# Altium schematic sheet Driver.SchDoc
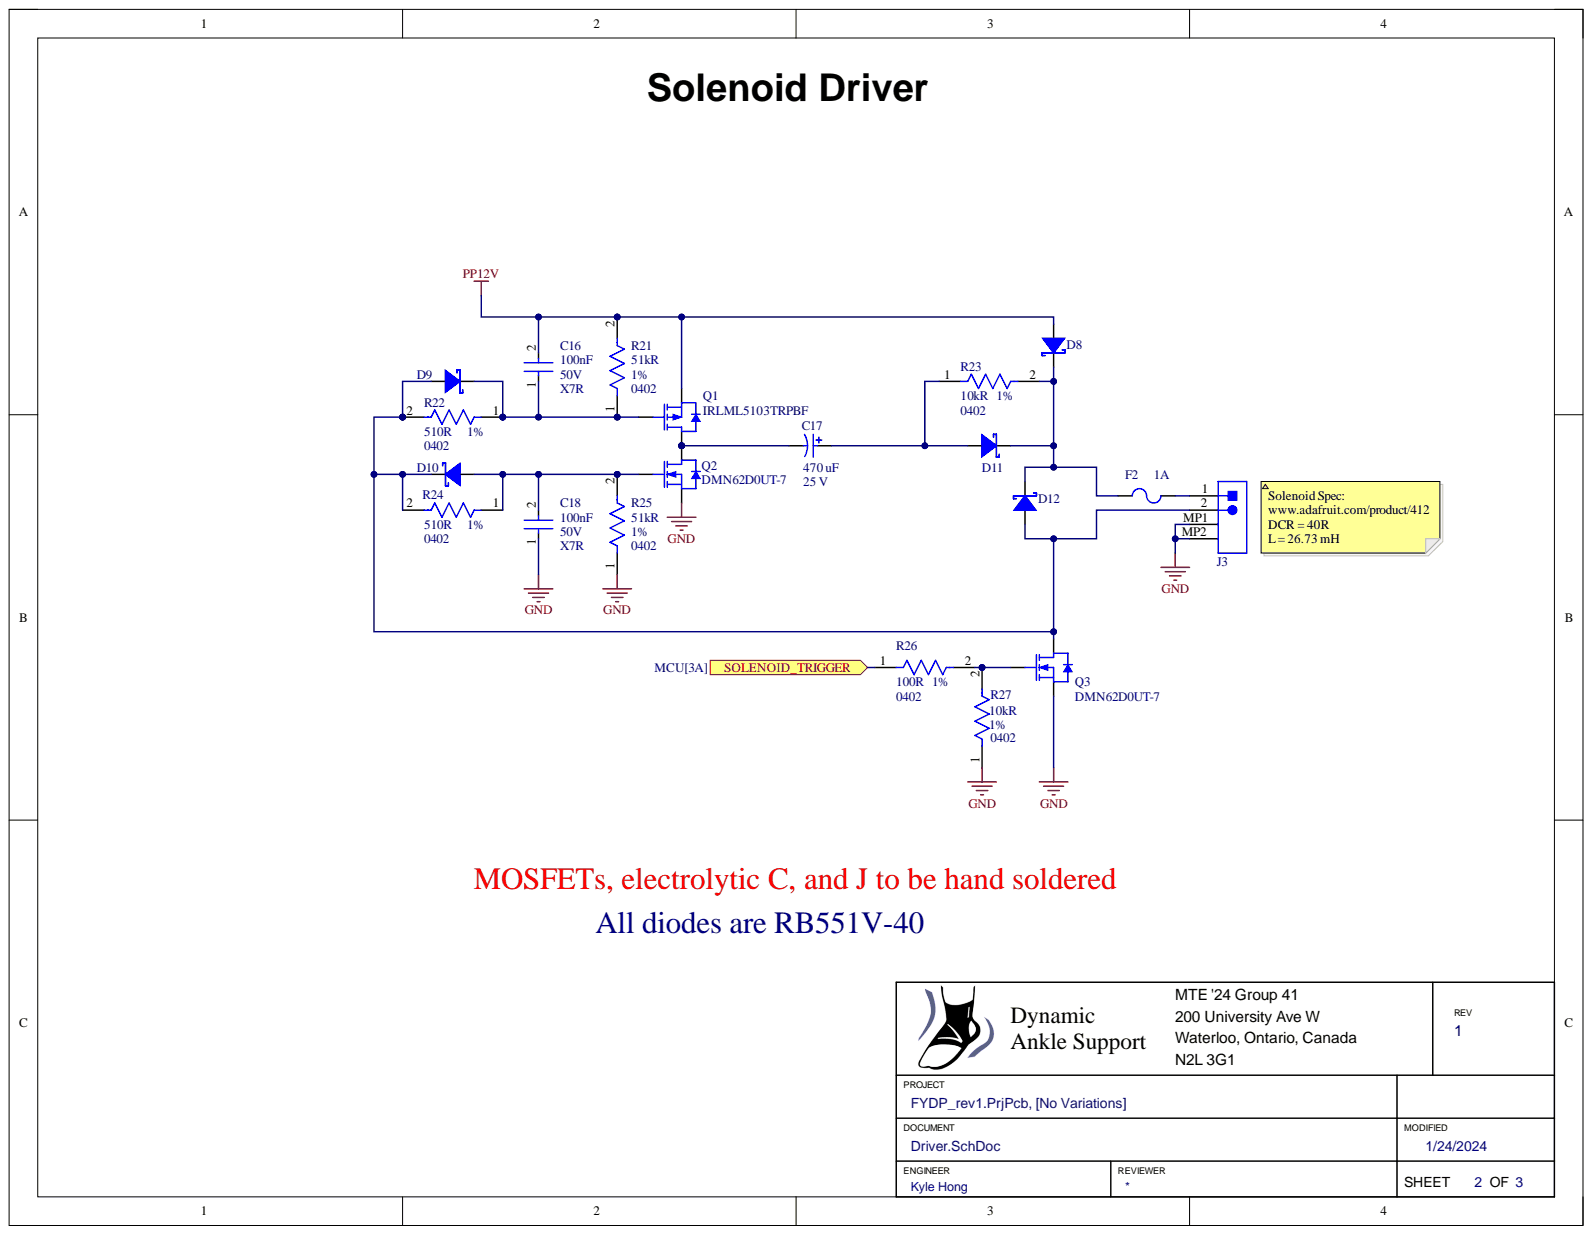
[diagram p0: Driver.SchDoc]

## Parts
C16  Capacitance: 100nF  Dielectric: X7R  Voltage Rating: 50V  [CAP0402]  (hidden comment: CL05B104KB54PNC)
C17  Rated Capacitance: 470 uF  Rated Voltage: 25 V  [NIC-CAPA2-10X10_V]  (hidden comment: UUD1E471MNL1GS)
C18  Capacitance: 100nF  Dielectric: X7R  Voltage Rating: 50V  [CAP0402]  (hidden comment: CL05B104KB54PNC)
D8  RB551V-40  [SOD-323]
D9  RB551V-40  [SOD-323]
D10  RB551V-40  [SOD-323]
D11  RB551V-40  [SOD-323]
D12  RB551V-40  [SOD-323]
F2  Current Rating: 1A  [FUSE_0603]  (hidden comment: 0467001.NRHF)
J3  S2B-PH-SM4-TB(LF)(SN)  [JST- S2B-PH-SM4-TB(LF)(SN)-2_V]  (value not drawn)
Q1  IRLML5103TRPBF  [SOT23-3]
Q2  DMN62D0UT-7  [SOT-523]
Q3  DMN62D0UT-7  [SOT-523]
R21  Resistance: 51kR  Tolerance: 1%  [RES0402]  (hidden comment: 0402WGF5102TCE)
R22  Resistance: 510R  Tolerance: 1%  [RES0402]  (hidden comment: 0402WGF5100TCE)
R23  Package: 0402  Resistance: 10kR  Tolerance: 1%  [RES0402]  (hidden comment: 0402WGF1002TCE)
R24  Package: 0402  Resistance: 510R  Tolerance: 1%  [RES0402]  (hidden comment: 0402WGF5100TCE)
R25  Package: 0402  Resistance: 51kR  Tolerance: 1%  [RES0402]  (hidden comment: 0402WGF5102TCE)
R26  Package: 0402  Resistance: 100R  Tolerance: 1%  [RES0402]  (hidden comment: 0402WGF1000TCE)
R27  Package: 0402  Resistance: 10kR  Tolerance: 1%  [RES0402]  (hidden comment: 0402WGF1002TCE)

## Ports
SOLENOID_TRIGGER

## Nets
GND: C18.1 J3.MP1 J3.MP2 Q2.2 Q3.2 R25.1 R27.1
PP12V: C16.2 D8.2 Q1.2 R21.2
SOLENOID_TRIGGER: R26.1 ; port SOLENOID_TRIGGER
NetC16_1: C16.1 D9.1 Q1.1 R21.1 R22.1
NetC17_1: C17.1 D11.2 R23.1
NetC17_2: C17.2 Q1.3 Q2.3
NetC18_2: C18.2 D10.2 Q2.1 R24.1 R25.2
NetD8_1: D8.1 D11.1 D12.1 F2.1 R23.2
NetD9_2: D9.2 D10.1 D12.2 J3.2 Q3.3 R22.2 R24.2
NetF2_2: F2.2 J3.1
NetQ3_1: Q3.1 R26.2 R27.2

## Library data of the parts
C16 C18 = CAP0402: 50V 100nF X7R ±10% 0402  Multilayer Ceramic Capacitors MLCC - SMD/SMT ROHS; Manufacturer: Samsung Electro-Mechanics; Tolerance: ±20%; Component Kind: Standard; Manufacturer Part Number: CL05B104KB54PNC; Package: 0402; Library Name: FYDP_library_sch.SchLib
C17 = CMP-0687-00002-1: Aluminum Electrolytic Capacitor, UD Series, Low Impedance, 470 uF, 25 V, + / - 20%, -55 to 105 degC, Chip Type, 10 x 10 mm D x L, Pb-Free, Reel; Manufacturer: Nichicon; Endurance Time: 5000 h; Impedance: 0.09 Ohm; Packing: Dia382mm Reel; Rated Ripple Current (at 100kHz): 670 mA; Tolerance Rated Capacitance: -20 to 20%; Component Kind: Standard; ComponentLink1Description: Manufacturer URL; ComponentLink1URL: http://www.nichicon.co.jp/; ComponentLink2Description: Datasheet; ComponentLink2URL: http://products.nichicon.co.jp/en/pdf/XJA043/e-ud.pdf; ComponentLink3Description: Package Specification; ComponentLink3URL: http://products.nichicon.co.jp/en/pdf/XJA043/e-ud.pdf; DatasheetVersion: 08/2012; Diameter: 10 mm; Length: 10 mm; Library Name: Altium Content Vault; Mounting Technology: Surface Mount; PackageDescription: 2-Pin Surface Mount Device, Diameter 10 mm, Height 10 mm, Pitch 8 mm; PackageReference: CAPAE-D10H10; PartNumber: UUD1E471MNL1GS; RoHS: TRUE
D8-D12 = RB551V-40: 40V 470mV@500mA 0.5A SOD-323  Schottky Barrier Diodes (SBD) ROHS; Manufacturer: Taiwan Semiconductor; Vf (Max): 470mV @ 0.5A; Component Kind: Standard; Io: 0.5A; Manufacturer Part Number: RB551V-40; Mounting Type: SMD; Package/Footprint: SOD-323; Vr (Max): 40V; Library Name: FYDP_library_sch.SchLib
F2 = FUSE0603: 50A SMD Fuse 1A 32V 32V 0603  Fuses ROHS; Manufacturer: Littelfuse; Component Kind: Standard; Manufacturer Part Number: 0467001.NRHF; Package: 0603; Supplier 1: Future Electronics; Supplier Part Number 1: 6130137; Voltage Rating: 32V; Library Name: FYDP_library_sch.SchLib
J3 = CMP-1755-00001-1: Male Header, Pitch 2 mm, 1 x 2 Position, Height 5.5 mm, -25 to 85 degC, RoHS, Tape and Reel; Current Rating: 3 A; Colour: Black; Component Kind: Standard; ComponentLink1Description: Manufacturer URL; ComponentLink1URL: http://www.jst.com/home2.html; ComponentLink2Description: Datasheet; ComponentLink2URL: http://www.jst-mfg.com/product/pdf/eng/ePH.pdf; Contact Finish: Lead; Contact Material: Bronze; Contact Plating: Tin; DatasheetVersion: Dec-12; Height: 8.13 mm; Lead Pitch: 0.1 inch; Length: 101.19 mm; Library Name: Altium Content Vault; Max Operating Temperature: 105degC; Min Operating Temperature: -65degC; Mount: Through Hole; Package Quantity: 100; PackageDescription: Male Header, Pitch 2 mm, 1 x 2 Position, Height 5.5 mm; PackageReference: S2B-PH-SM4-TB(LF)(SN)-2; Packaging: Bulk; Pins: 40; Radiation Hardening: No; RoHSCompliant: True; Width: 2.34 mm
Q1 = IRLML5103TRPBF: P-Channel 30 V 760mA (Ta) 540mW (Ta) Surface Mount Micro3™/SOT-23; Manufacturer 1: Infineon Technologies; Vdss: 30V; Vgs (Max): ±20V; Component Kind: Standard; Id: 760mA; Manufacturer Part Number 1: IRLML5103TRPBF; Mounting Type: SMD; Power Dissipation (Max): 540mW (Ta); Supplier 1: Mouser; Supplier Part Number 1: 942-IRLML5103TRPBF; Library Name: FYDP_library_sch.SchLib
Q2 Q3 = DMN62D0UT-7: NFET 60 V 320mA (Ta) 230mW (Ta) Surface Mount SOT-523; Vdss: 60V; Vgs (Max): ±20V; Vgs(th) (Max) @ Id: 1V @ 250uA; Component Kind: Standard; Id @ 25°C: 320mA; Package: SOT-523; Supplier 1: Digikey; Supplier Part Number 1: DMN62D0UT-7DICT-ND; Library Name: FYDP_library_sch.SchLib
R21 R25 = RES0402: 62.5mW Thick Film Resistors ±100ppm/℃ ±1% 51kΩ 0402  Chip Resistor - Surface Mount ROHS; Manufacturer: UNI-ROYAL(Uniroyal Elec); Component Kind: Standard; Manufacturer Part Number: 0402WGF5102TCE; Power Rating: 62.5mW; Library Name: FYDP_library_sch.SchLib
  R21: Package: 0402
R22 R24 = RES0402: 62.5mW Thick Film Resistors ±100ppm/℃ ±1% 510Ω 0402  Chip Resistor - Surface Mount ROHS; Manufacturer: UNI-ROYAL(Uniroyal Elec); Component Kind: Standard; Manufacturer Part Number: 0402WGF5100TCE; Power Rating: 62.5mW; Library Name: FYDP_library_sch.SchLib
  R22: Package: 0402
R23 R27 = RES0402: 62.5mW Thick Film Resistors ±100ppm/℃ ±1% 10kΩ 0402  Chip Resistor - Surface Mount ROHS; Manufacturer: UNI-ROYAL(Uniroyal Elec); Component Kind: Standard; Manufacturer Part Number: 0402WGF1002TCE; Power Rating: 62.5mW; Library Name: FYDP_library_sch.SchLib
R26 = RES0402: 62.5mW Thick Film Resistors ±1% ±200ppm/℃ 100Ω 0402  Chip Resistor - Surface Mount ROHS; Manufacturer: UNI-ROYAL(Uniroyal Elec); Component Kind: Standard; Manufacturer Part Number: 0402WGF1000TCE; Power Rating: 62.5mW; Library Name: FYDP_library_sch.SchLib
